# Altium schematic sheet CAN_IO.SchDoc
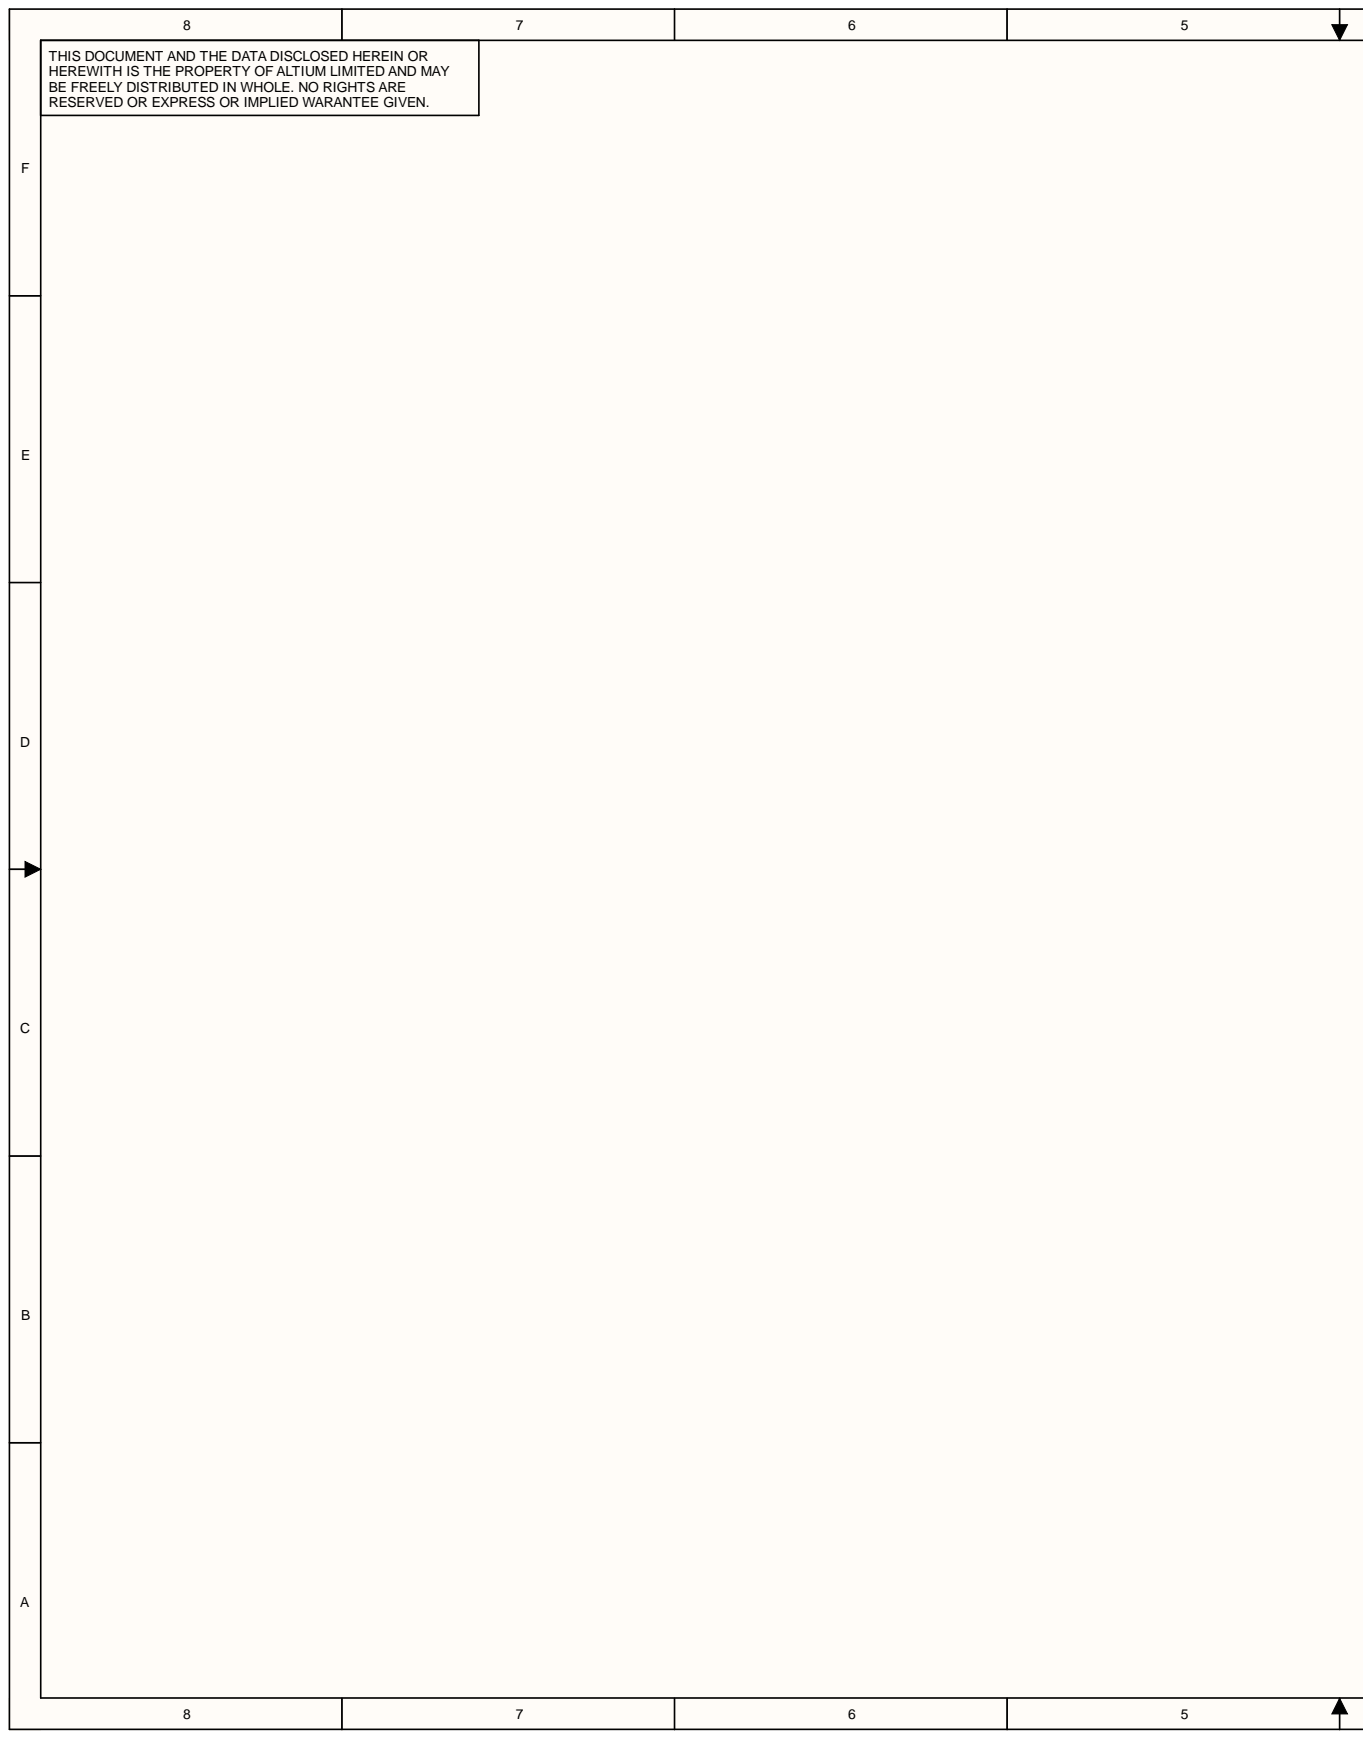
[diagram p0: CAN_IO.SchDoc, left]
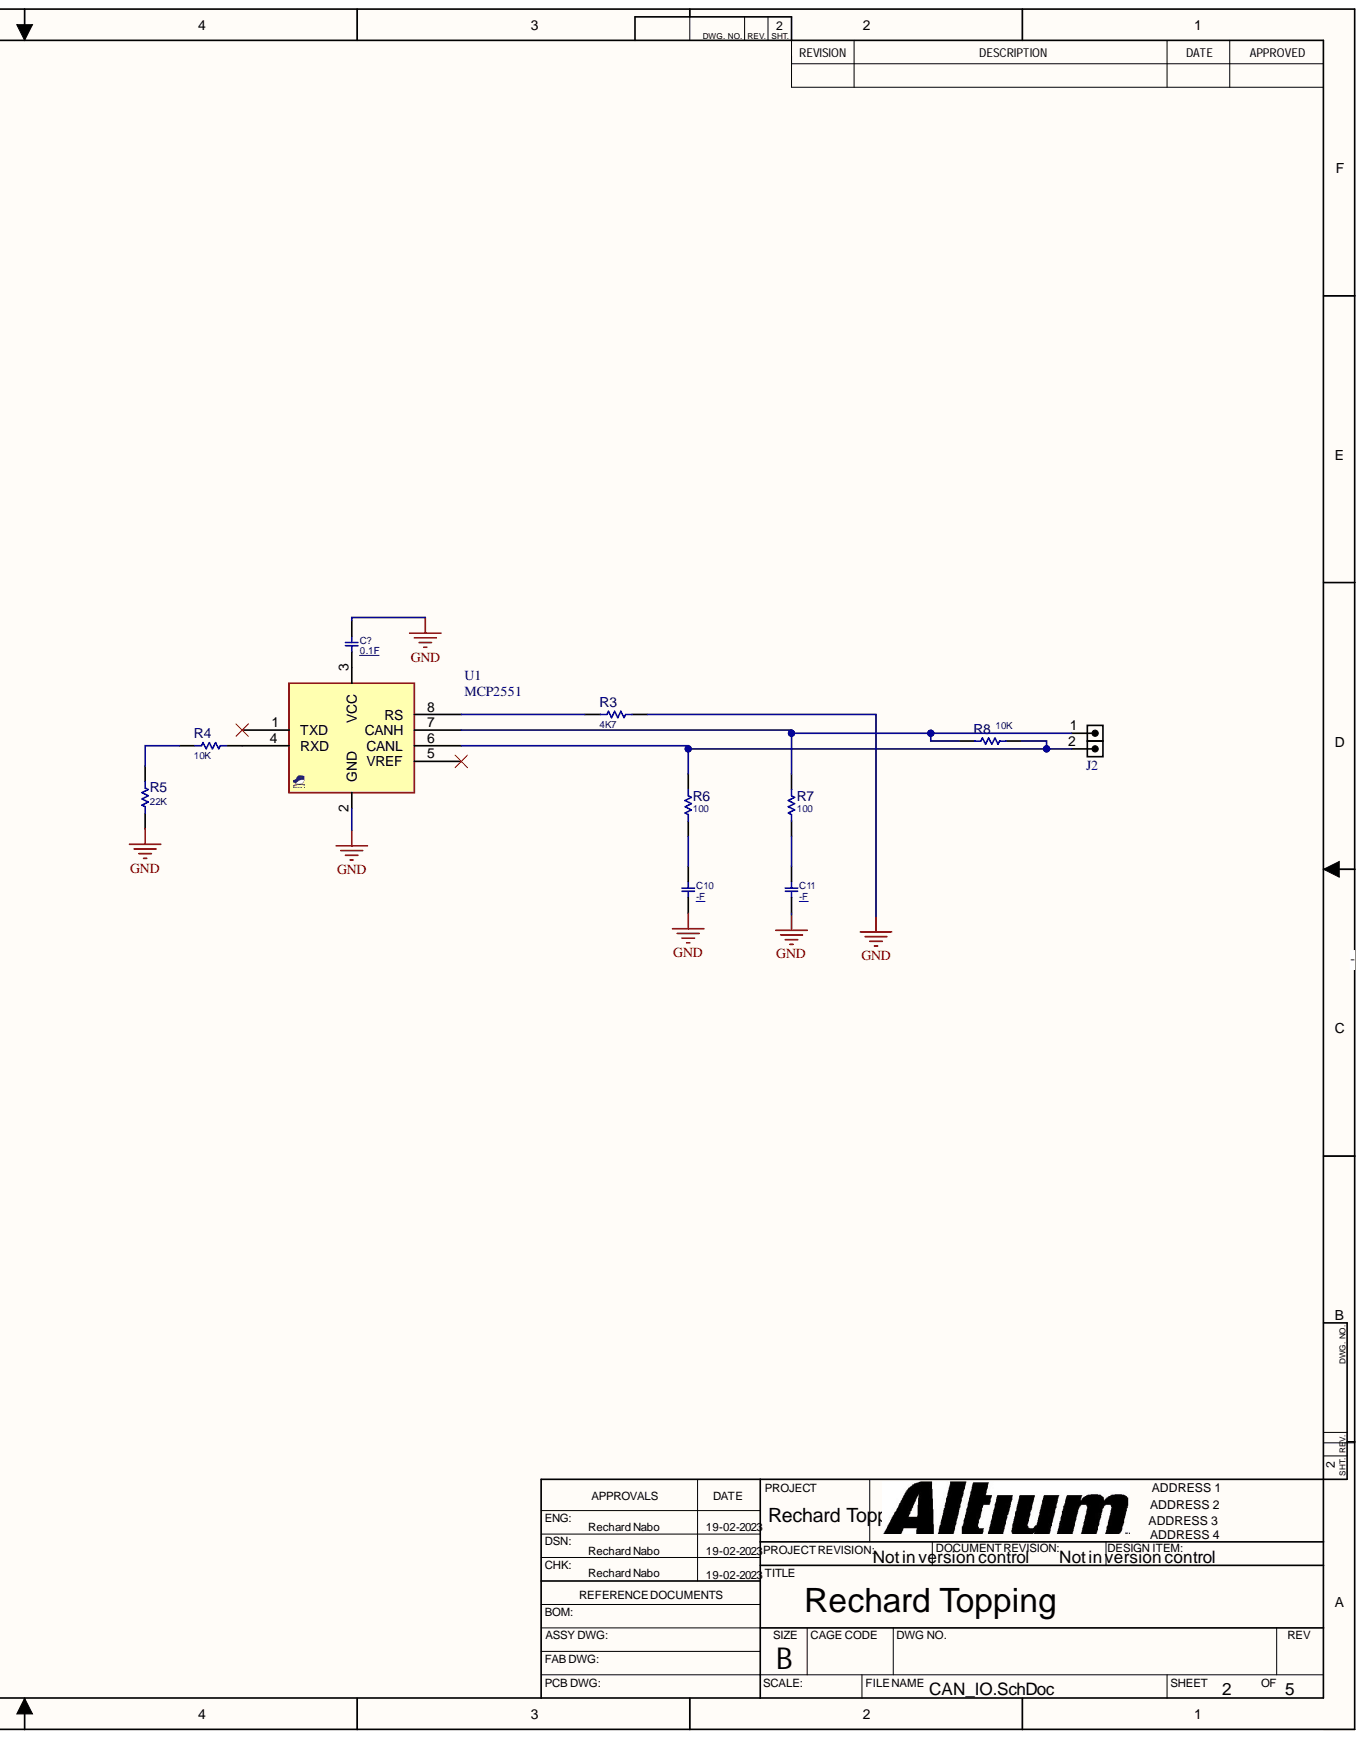
[diagram p1: CAN_IO.SchDoc, right]

## Parts
C10  -F  [C0805]
C11  -F  [C0805]
C?  0.1F  [C0805]
J2  HDRM2  [HDR_M_1X2]  (value not drawn)
R3  4K7  [R0805]
R4  10K  [R0805]
R5  22K  [R0805]
R6  100  [R0805]
R7  100  [R0805]
R8  10K  [R0805]
U1  MCP2551  [SO_8]

## Nets
GND: C10.1 C11.1 C?.2 R3.2 R5.1 U1.2(GND)
NetC10_2: C10.2 R6.1
NetC11_2: C11.2 R7.1
NetC?_1: C?.1 U1.3(VCC)
NetJ2_1: J2.1 R7.2 R8.2 U1.7(CANH)
NetJ2_2: J2.2 R6.2 R8.1 U1.6(CANL)
NetR3_1: R3.1 U1.8(RS)
NetR4_1: R4.1 R5.2
NetR4_2: R4.2 U1.4(RXD)

Not connected: U1 1(TXD) 5(VREF)

## Library data of the parts
C10 C11 C? = CAP_SMD_MLCC_0805: CAP, MLCC, -F, -V, MATERIAL, -%, 0201; MANF_P#: MANF_PART#; PARAM: -, -%; ASSEMBLY: ASSEMBLY; Component Kind: Standard; Library Name: passive_smd.SchLib; MANF: -; PARAM2: -V; PRICE: 100= 1$
  C10 C11: VALUE: -F
  C?: VALUE: 0.1F
J2 = HDR_M_1X2: CONN, HEADER, Male, pitch: 2.54mm, 2POS; Component Kind: Standard; Library Name: conn_header_2.54_st.SchLib; VALUE: HDRM2
R3-R8 = RES_SMD_0805: RESISTOR, SMD; DESC: DESCRIPTION; DIST#: MOUSER; PARAM: -W; PARAM2: 1%; ASSEMBLY: ASSEMBLY; Component Kind: Standard; Library Name: passive_smd.SchLib; MANF: -; MANF_P#: -; PRICE: 100= 0.5$
  R3: VALUE: 4K7
  R4 R8: VALUE: 10K
  R5: VALUE: 22K
  R6 R7: VALUE: 100
U1 = MCP2551_SOP8: Can, Transceiver, High Speed, 5V (VDD); MANF: MICROCHIP; MANF_P#: MCP2551-I/SN; PARAM2: 5V (VDD), 12/24V; Component Kind: Standard; PARAM: PARAM; PRICE: 3000toman@PAYA; VALUE: MCP2551; Library Name: can_phy.SchLib
